# Altium schematic sheet COMM LEVEL SHIFTER.SchDoc("COMM LEVEL SHIFTER")
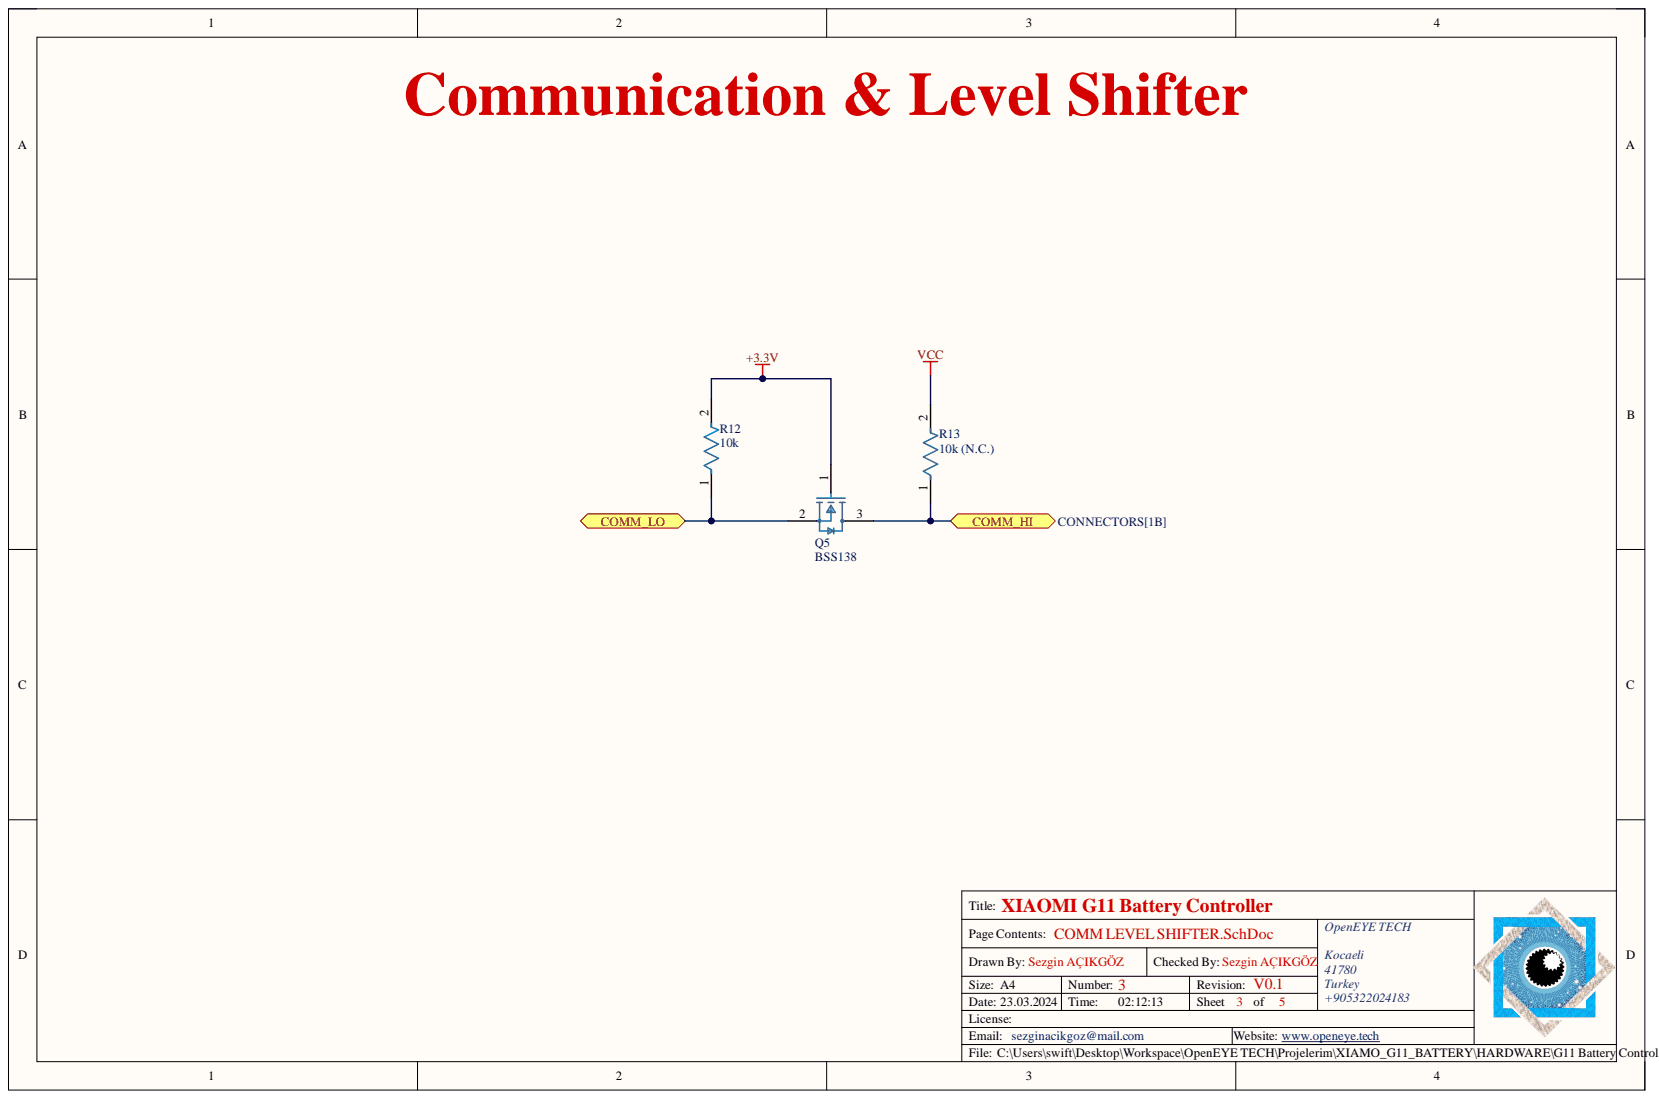
[diagram p0: COMM LEVEL SHIFTER.SchDoc]

## Parts
Q5  BSS138  [FP-318-08-IPC_B]
R12  10k  [FP-RP731J-MFG]
R13  10k (N.C.)  [FP-RP731J-MFG]

## Ports
COMM_HI, COMM_LO

## Nets
+3.3V: Q5.1 R12.2
VCC: R13.2
COMM_HI: Q5.3 R13.1 ; port COMM_HI
COMM_LO: Q5.2 R12.1 ; port COMM_LO

## Library data of the parts
Q5 = CMP-07173-000101-1: MOSFET N-CH 50V 220MA SOT-23; Drain to Source Resistance: 3.5R; Element Configuration: Single; Fall Time: 9ns; Gate to Source Voltage (Vgs): 20V; Max Power Dissipation: 360mW; Nominal Vgs: 1.3V; Number of Elements: 1; On-State Resistance: 6R; Turn-Off Delay Time: 20ns; Turn-On Delay Time: 2.5ns; Input Capacitance: 27pF; Case/Package: SOT-23; Component Kind: Standard; Contact Plating: Tin; Continuous Drain Current (ID): 220mA; Current Rating: 220mA; Drain to Source Breakdown Voltage: 50V; Drain to Source Voltage (Vdss): 50V; Dual Supply Voltage: 50V; Height: 0.93mm; LCSC Part #: C5224260; Lead Free: Lead Free; Length: 2.92mm; Library Name: Altium Content Vault; Max Operating Temperature: 150°C; Min Operating Temperature: -55°C; Mount: Surface Mount; Number of Pins: 3; Package Quantity: 1; Packaging: Tape and Reel; Power Dissipation: 360mW; Radiation Hardening: No; Rds On Max: 3.5R; REACH SVHC: No SVHC; Rise Time: 9ns; Termination: SMD/SMT; Threshold Voltage: 1.3V; Voltage Rating (DC): 50V; Weight: 0.03g; Width: 1.3mm
R12 R13 = CMP-03211-008596-1: TE CONNECTIVITY / HOLSWORTHY SMD Chip Resistor, Thin Film, 8.45Ω, 50 V, 0603 [1608 Metric], 100 mW, 0.1% , RP73 Series; Manufacturer Part Number: RP73D1J8R45BTDG; Power Rating: 0.1W; Resistance: 10kΩ; Temperature Coefficient: 15ppm/°C; Value: 10kΩ; Voltage Rating: 75V; Automotive: No; Case/Package: 603; Category: Res; Component Kind: Standard; ComponentLink2Description: Datasheet; ComponentLink2URL: https://www.te.com/commerce/DocumentDelivery/DDEController?Action=srchrtrv&DocNm=1773272&DocType=DS&DocLang=English; Composition: Thin Film; Device Class L1: Passive Components; Device Class L2: Resistors; Device Class L3: Chip SMD Resistors; Height: 0.55mm; Lead Free: Yes; Library Name: Altium Content Vault; Manufacturer: TE Connectivity; Octopart: https://octopart.com/rp73d1j8r45btdg-te+connectivity-42630912; octopart_url: https://octopart.com/rp73d1j8r45btdg-te+connectivity-42630912; Package: 603; packaging: cut tape; RoHS: TRUE; Series: RP73; Temperature: -55°C to +105°C; Temperature Range High: +105°C; Temperature Range Low: -55°C; Tolerance: 0.1%; Ipc Land Pattern Name: RESC15580X45
